# Altium schematic sheet CPU_EPC.SchDoc(CPU_EPC)
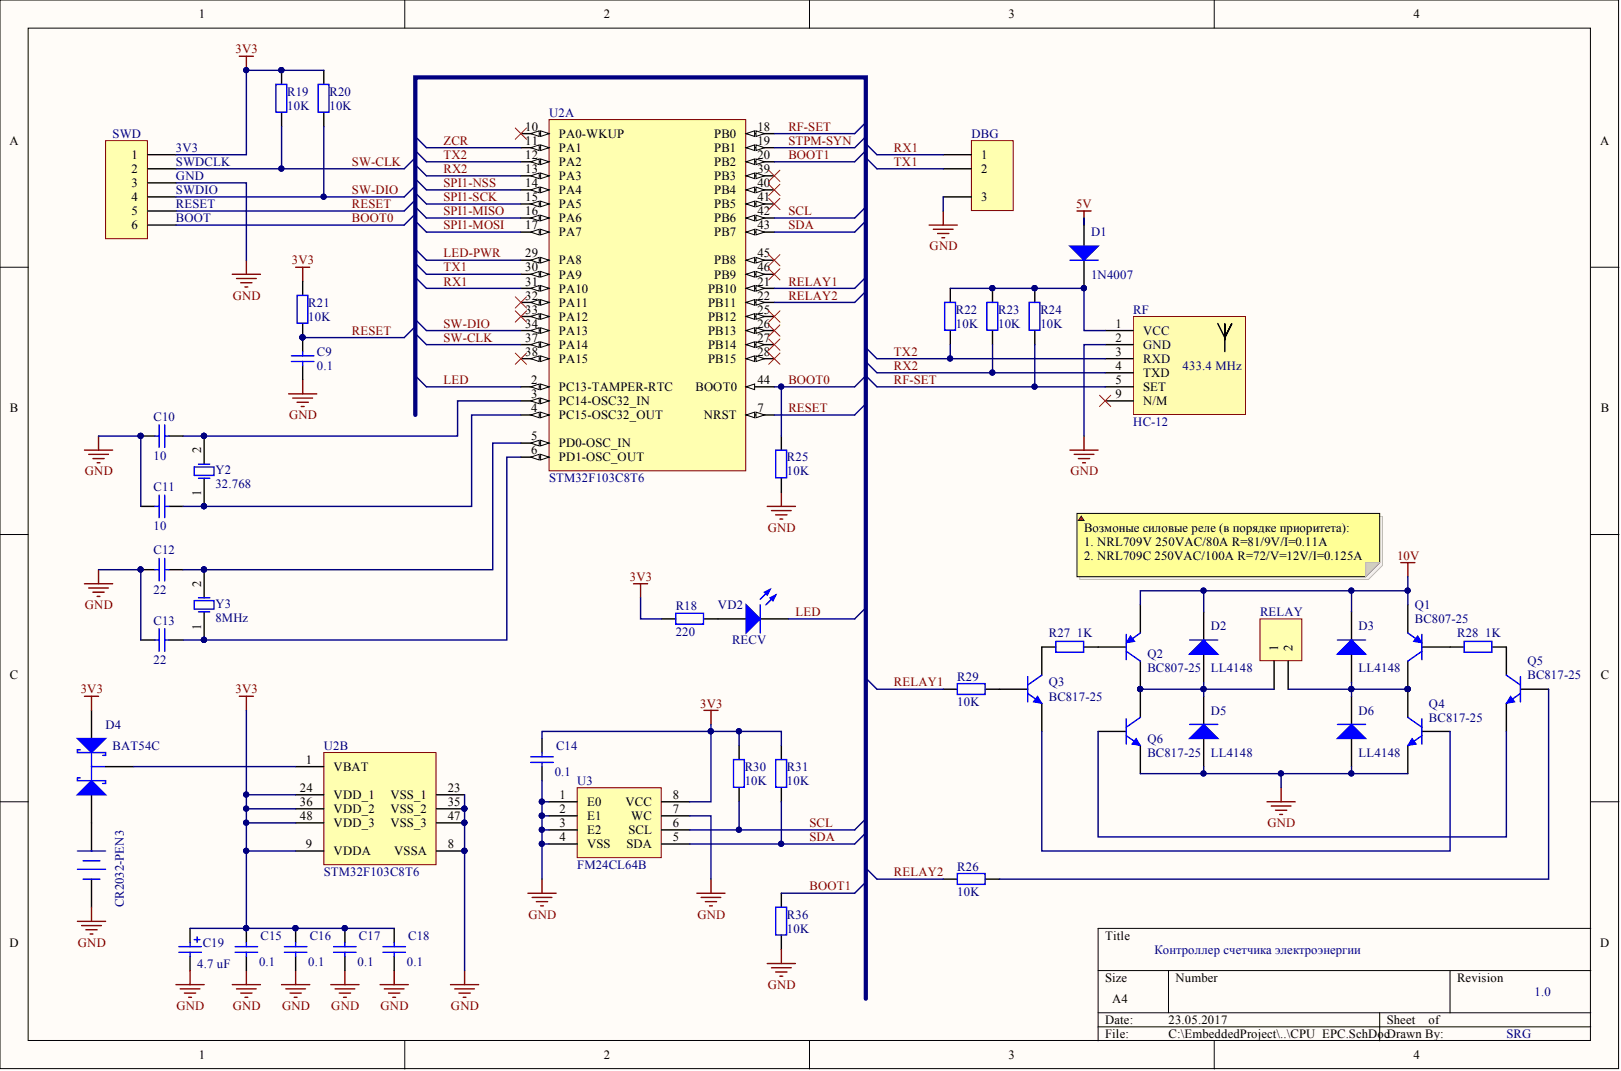
[diagram p0: CPU_EPC.SchDoc]

## Parts
BAT  value CR2032-PEN3  [CR2032-PEN3]  (hidden comment: BAT 3V3)
C9  value 0.1  [C1206]  (hidden comment: Cap)
C10  value 10  [C1206]  (hidden comment: Cap)
C11  value 10  [C1206]  (hidden comment: Cap)
C12  value 22  [C1206]  (hidden comment: Cap)
C13  value 22  [C1206]  (hidden comment: Cap)
C14  value 0.1  [C1206]  (hidden comment: Cap)
C15  value 0.1  [C1206]  (hidden comment: Cap)
C16  value 0.1  [C1206]  (hidden comment: Cap)
C17  value 0.1  [C1206]  (hidden comment: Cap)
C18  value 0.1  [C1206]  (hidden comment: Cap)
C19  value 4.7 uF  [B]  (hidden comment: Polarized Capacitor)
D1  value 1N4007  PartNumber: 1N4007  [DO-41-300]  (hidden comment: Diode)
D2  value LL4148  PartNumber: LL4148  [D1206]  (hidden comment: Diode)
D3  value LL4148  PartNumber: LL4148  [D1206]  (hidden comment: Diode)
D4  value BAT54C  [SOT23_BAT54]  (hidden comment: Schottky Barrier Double Diode)
D5  value LL4148  PartNumber: LL4148  [D1206]  (hidden comment: Diode)
D6  value LL4148  PartNumber: LL4148  [D1206]  (hidden comment: Diode)
DBG  Header 3  [HDR1X4-1]  (value not drawn)
Q1  BC807-25  [SOT-23A_N]
Q2  BC807-25  [SOT-23A_N]
Q3  BC817-25  [SOT-23A_N]
Q4  BC817-25  [SOT-23A_N]
Q5  BC817-25  [SOT-23A_N]
Q6  BC817-25  [SOT-23A_N]
R18  value 220  [R1206]  (hidden comment: Res2)
R19  value 10K  [R1206]  (hidden comment: Res2)
R20  value 10K  [R1206]  (hidden comment: Res2)
R21  value 10K  [R1206]  (hidden comment: Res2)
R22  value 10K  [R1206]  (hidden comment: Res2)
R23  value 10K  [R1206]  (hidden comment: Res2)
R24  value 10K  [R1206]  (hidden comment: Res2)
R25  value 10K  [R1206]  (hidden comment: Res2)
R26  value 10K  [R1206]  (hidden comment: Res2)
R27  value 1K  [R1206]  (hidden comment: Res2)
R28  value 1K  [R1206]  (hidden comment: Res2)
R29  value 10K  [R1206]  (hidden comment: Res2)
R30  value 10K  [R1206]  (hidden comment: Res2)
R31  value 10K  [R1206]  (hidden comment: Res2)
R36  value 10K  [R1206]  (hidden comment: Res2)
RELAY  Header 2  [HDR1X2]  (value not drawn)
RF  HC-12  [HC-12-INTANT]
SWD  Header 6  [HDR1X6]  (value not drawn)
U2  STM32F103C8T6  [STM-LQFP48_N]  (parts A,B)
U3  FM24CL64B  [SO8_M]
VD2  RECV  [LED1206]
Y2  value 32.768  [LED]  (hidden comment: XTAL)
Y3  value 8MHz  [HC49/4H_SMX]  (hidden comment: XTAL)

## Nets
3V3: C14.2 C15.2 C16.2 C17.2 C18.2 C19.1 D4.2 R18.1 R19.2 R20.2 R21.2 R30.2 R31.2 SWD.1 U2.9(VDDA) U2.24(VDD_1) U2.36(VDD_2) U2.48(VDD_3) U3.8(VCC)
5V: D1.1
10V: D2.2 D3.2 Q1.2 Q2.2
GND: BAT.2 C9.1 C10.1 C11.1 C12.1 C13.1 C14.1 C15.1 C16.1 C17.1 C18.1 C19.2 D5.1 D6.1 DBG.3 Q4.2 Q6.2 R25.1 R36.1 RF.2(GND) SWD.3 U2.8(VSSA) U2.23(VSS_1) U2.35(VSS_2) U2.47(VSS_3) U3.1(E0) U3.2(E1) U3.3(E2) U3.4(VSS) U3.7(WC)
BOOT0: R25.2 SWD.6 U2.44(BOOT0)
BOOT1: R36.2 U2.20(PB2)
LED: U2.2(PC13-TAMPER-RTC) VD2.2
LED-PWR: U2.29(PA8)
RELAY1: R29.2 U2.21(PB10)
RELAY2: R26.2 U2.22(PB11)
RESET: C9.2 R21.1 SWD.5 U2.7(NRST)
RF-SET: R24.1 RF.5(SET) U2.18(PB0)
RX1: DBG.1 U2.31(PA10)
RX2: R23.1 RF.4(TXD) U2.13(PA3)
SCL: R30.1 U2.42(PB6) U3.6(SCL)
SDA: R31.1 U2.43(PB7) U3.5(SDA)
SPI1-MISO: U2.16(PA6)
SPI1-MOSI: U2.17(PA7)
SPI1-NSS: U2.14(PA4)
SPI1-SCK: U2.15(PA5)
STPM-SYN: U2.19(PB1)
SW-CLK: R19.1 SWD.2 U2.37(PA14)
SW-DIO: R20.1 SWD.4 U2.34(PA13)
TX1: DBG.2 U2.30(PA9)
TX2: R22.1 RF.3(RXD) U2.12(PA2)
ZCR: U2.11(PA1)
NetBAT_1: BAT.1 D4.1
NetC10_2: C10.2 U2.3(PC14-OSC32_IN) Y2.2
NetC11_2: C11.2 U2.4(PC15-OSC32_OUT) Y2.1
NetC12_2: C12.2 U2.5(PD0-OSC_IN) Y3.2
NetC13_2: C13.2 U2.6(PD1-OSC_OUT) Y3.1
NetD1_2: D1.2 R22.2 R23.2 R24.2 RF.1(VCC)
NetD2_1: D2.1 D5.2 Q2.3 Q6.3 RELAY.1
NetD3_1: D3.1 D6.2 Q1.3 Q4.3 RELAY.2
NetD4_3: D4.3 U2.1(VBAT)
NetQ1_1: Q1.1 R28.2
NetQ2_1: Q2.1 R27.1
NetQ3_1: Q3.1 R29.1
NetQ3_2: Q3.2 Q4.1
NetQ3_3: Q3.3 R27.2
NetQ5_1: Q5.1 R26.1
NetQ5_2: Q5.2 Q6.1
NetQ5_3: Q5.3 R28.1
NetR18_2: R18.2 VD2.1
N00009:

Not connected: RF 9(N/M); U2 10(PA0-WKUP) 25(PB12) 26(PB13) 27(PB14) 28(PB15) 32(PA11) 33(PA12) 38(PA15) 39(PB3) 40(PB4) 41(PB5) 45(PB8) 46(PB9)

## Library data of the parts
BAT = Battery: Multicell Battery; DeliveryTime: 7; Value: CR2032-PEN3; Component Kind: Standard; Library Name: Miscellaneous Devices.IntLib; PartNumber: CR2032-PEN3; Price: 42.00
C9 C14-C18 = Cap: Capacitor; PartNumber: GRM31CR72A104K; DeliveryTime: 7; Component Kind: Standard; Library Name: Miscellaneous Devices.IntLib; Price: 10.00; Signal Integrity: Cap; Simulation: CAP
C10 C11 = Cap: Capacitor; PartNumber: GRM31A7U3D100JW31D; DeliveryTime: 7; Component Kind: Standard; Library Name: Miscellaneous Devices.IntLib; Price: 4.40; Signal Integrity: Cap; Simulation: CAP
C12 C13 = Cap: Capacitor; PartNumber: GRM3165C1H220J; DeliveryTime: 7; Component Kind: Standard; Library Name: Miscellaneous Devices.IntLib; Price: 5.0; Signal Integrity: Cap; Simulation: CAP
C19 = Cap Pol2: PartNumber: TECAP, 4.7мкф, 16В, тип В, 10% SMD; DeliveryTime: 7; Value: 4.7 uF; Component Kind: Standard; Description: Polarized Capacitor; Library Name: Miscellaneous Devices.IntLib; Price: 12.00; Signal Integrity: Cap Pol; Simulation: CAP
D1-D3 D5 D6 = Diode: Default Diode; DeliveryTime: 7; Component Kind: Standard; Library Name: Miscellaneous Devices.IntLib; Signal Integrity: Diode; Simulation: DIODE
  D1: Price: 3.00
  D2 D3 D5 D6: Price: 1.0
D4 = BAT54C: DeliveryTime: 7; Component Kind: Standard; Description: Schottky Barrier Double Diode; Library Name: Philips Discrete Diode - Schottky.IntLib; PartNUmber: BAT54C; Price: 4.00; Signal Integrity: BAT54C; Simulation: BAT54C
DBG = Header 3: Header, 3-Pin; Component Kind: Standard; LatestRevisionDate: 17-Jul-2002; LatestRevisionNote: Re-released for DXP Platform.; Library Name: Schlib1.SchLib; Signal Integrity: Connector; value: Header 3; Publisher: Altium Limited
Q1 Q2 = BC807-25: PNP General Purpose Amplifier; PartNumber: BC807-25.215; DeliveryTime: 7; Component Kind: Standard; Library Name: FSC Discrete BJT.IntLib; Price: 2.00; Signal Integrity: BC807-25; Simulation: BC807-25; Value: BC807-25
Q3-Q6 = BC817-25: NPN General Purpose Amplifier; PartNumber: BC817-25.215; DeliveryTime: 7; Component Kind: Standard; Library Name: FSC Discrete BJT.IntLib; Price: 2.00; Signal Integrity: BC817-25; Simulation: BC817-25; value: BC817-25
R18 = Res2: Resistor; PartNumber: RC1206JK-07220RL; DeliveryTime: 7; Component Kind: Standard; Library Name: Miscellaneous Devices.IntLib; Price: 0.90; Signal Integrity: Res; Simulation: RESISTOR
R19-R26 R29-R31 R36 = Res2: Resistor; PartNumber: RC1206JK-0710KL; DeliveryTime: 7; Component Kind: Standard; Library Name: Miscellaneous Devices.IntLib; Price: 0.90; Signal Integrity: Res; Simulation: RESISTOR
R27 R28 = Res2: Resistor; PartNumber: RC1206FK-071KL; DeliveryTime: 7; Component Kind: Standard; Library Name: Miscellaneous Devices.IntLib; Price: 0.90; Signal Integrity: Res; Simulation: RESISTOR
RELAY = Header 2: Header, 2-Pin; Component Kind: Standard; LatestRevisionDate: 17-Jul-2002; LatestRevisionNote: Re-released for DXP Platform.; Library Name: Miscellaneous Connectors.IntLib; Signal Integrity: Connector; Publisher: Altium Limited
RF = HC-12: Wireless Serial Port Communication Module; Component Kind: Standard; Library Name: Schlib1.SchLib; PartNUmber: HC-12; Price: 200.00; Value: HC-12
SWD = Header 6: Header, 6-Pin; Component Kind: Standard; LatestRevisionDate: 17-Jul-2002; LatestRevisionNote: Re-released for DXP Platform.; Library Name: Miscellaneous Connectors.IntLib; Signal Integrity: Connector; Publisher: Altium Limited
U2 = STM32F103C8T6: ARM Cortex-M3 32-bit MCU, 64 KB Flash, 20 KB Internal RAM, 37 I/Os, 48-pin LQFP, -40 to 85 degC, Tray; DeliveryTime: 7; Component Kind: Standard; Library Name: STMicroelectronics STM32 F1.IntLib; PartNumber: STM32F103C8T6; Price: 140.00; Value: STM32F103C8T6
U3 = FM24CL64B: DeliveryTime: 5; Component Kind: Standard; Description: FM24CL64B; Library Name: Schlib1.SchLib; PartNumber: FM24CL64B; Price: 200.00; Value: FM24CL64B
VD2 = LED0: SMD LED; PartNumber: KPDT-3216PGC; DeliveryTime: 7; value: GREEN; Component Kind: Standard; Library Name: Miscellaneous Devices.IntLib; Price: 9.00; Simulation: LED0
Y2 = XTAL: Crystal Oscillator; PartNumber: MCRJ332768F1220HOW; DeliveryTime: 21; Component Kind: Standard; Library Name: Miscellaneous Devices.IntLib; Price: 28; Simulation: XTAL
Y3 = XTAL: Crystal Oscillator; PartNumber: LFXTAL020423; DeliveryTime: 21; Component Kind: Standard; Library Name: Miscellaneous Devices.IntLib; Price: 57.00; Simulation: XTAL
